# Altium schematic sheet transmitter.SchDoc
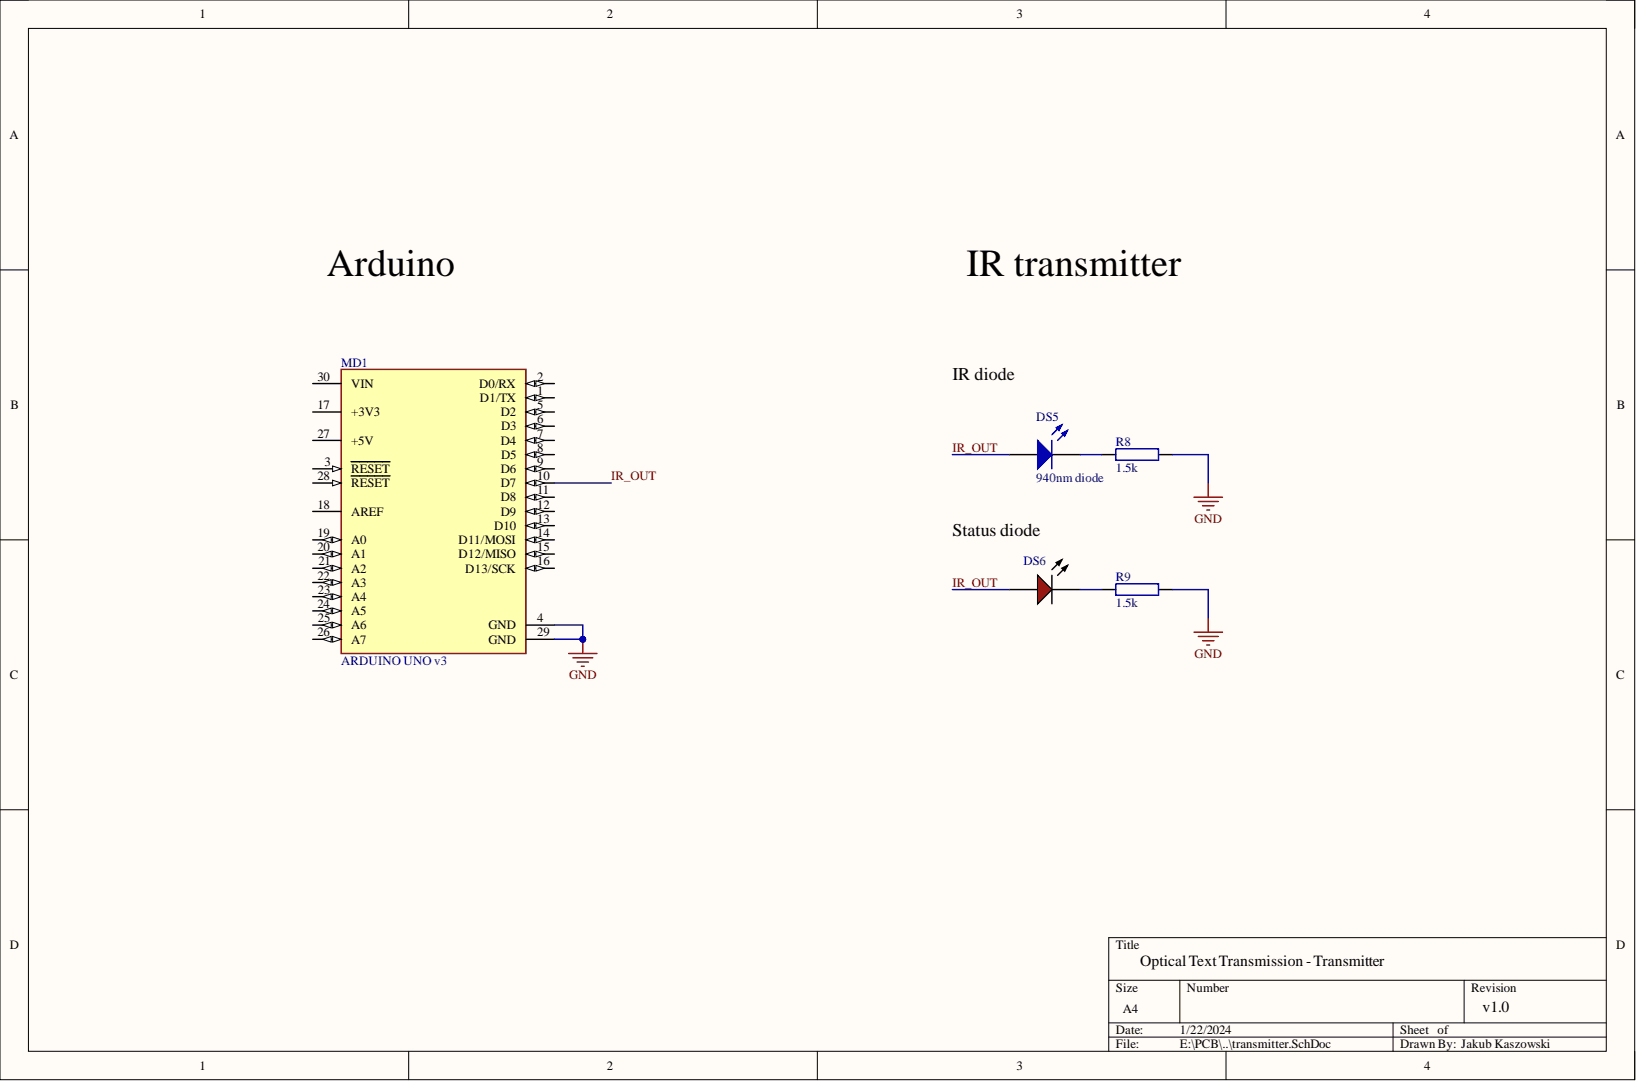
[diagram p0: transmitter.SchDoc]

## Parts
DS5  940nm diode  [VISH-TSAL6200]
DS6  TLMS1100-GS08  [VISH-TLMX1100_V]  (value not drawn)
MD1  ARDUINO UNO v3  [FP-A000005-MFG]
R8  1.5k  [R0603]
R9  1.5k  [R0603]

## Nets
GND: MD1.4(GND) MD1.29(GND) R8.2 R9.2
IR_OUT: DS5.1 DS6.1 MD1.10(D7)
NetDS5_2: DS5.2 R8.1
NetDS6_2: DS6.2 R9.1

Not connected: MD1 1(D1/TX) 2(D0/RX) 3(RESET) 5(D2) 6(D3) 7(D4) 8(D5) 9(D6) 11(D8) 12(D9) 13(D10) 14(D11/MOSI) 15(D12/MISO) 16(D13/SCK) 17(+3V3) 18(AREF) 19(A0) 20(A1) 21(A2) 22(A3) 23(A4) 24(A5) 25(A6) 26(A7) 27(+5V) 28(RESET) 30(VIN)

## Library data of the parts
DS5 = CMP-2000-07327-1: High Power Infrared Emitting Diode, GaAlAs, MQW, 1.35 V, 100 mA, -40 to 85 degC, 2-Pin THD, RoHS, Bulk; Manufacturer: Vishay; DELETED: Compliant; Forward Current: 100mA; Forward Voltage: 3V; High Brightness: Yes; Lens Type: Colored; Lum Intensity: 500mW/srmcd; Luminous Intensity: 500mW/sr; Main Category: IrLED; Number of  Elements: 1; Peak Wavelength: 950nm; Power Dissipation: 160W; Product Depth (mm): 5.8mm; Product Height (mm): 8.7mm; Rad Hardened: No; Reverse Voltage: 5V; Shape Type: Circular; Viewing Angle: 34deg; Chip Material: GaAlAs; Component Kind: Standard; Datasheet URL: http://www.vishay.com/docs/81010/tsal6200.pdf; Datasheet Version: Rev. 2.4, 03/2014; Lens Dimensions: 5x5x7.7mm; Library Name: Altium Content Vault; Manufacturer URL: http://www.vishay.com/; Mounting: ThroughHole; Mounting Technology: ThroughHole; Operating Temp Range: -40Cto85C; Operating Temperature Classification: Industrial; Package Description: 2-Pin Through Hole Device, Dia 5.8 mm, Pitch 2.54 mm; Package Reference: TSAL6200; Package Type: T-13/4; Packaging: Bulk; Pin Count: 2; Product Length (mm): 5.8mm; Test Current (It): 100mA; Type: IrLED
DS6 = CMP-1666-00027-1: LED, RED, 2.1 V, -40 to 100 degC, 2-Pin SMD (0603), RoHS, Tape and Reel; Capacitance: 12 pF; Resistance: 100000000000 Ohm; Tolerance: 5%; Type (Capacitors): C0G; Voltage Rating: 50 V; Case Code (Imperial): 0603; Case Code (Metric): 1608; Case/Package: 0603; Component Kind: Standard; ComponentLink1Description: Manufacturer URL; ComponentLink1URL: http://www.vishay.com/; ComponentLink2Description: Datasheet; ComponentLink2URL: http://www.vishay.com/docs/83173/tlmb1100.pdf; DatasheetVersion: Rev. 2.7, 03/2016; Height: 0.8 mm; Length: 1.6 mm; Library Name: Altium Content Vault; Max Operating Temperature: 125degC; Min Operating Temperature: -55degC; Mount: Surface Mount; Package Quantity: 4000; PackageDescription: 2-Pin Surface Mount Device, Body 1.6 x 0.8 mm; PackageReference: TLMX1100; Packaging: Tape and Reel; Pins: 2; Radiation Hardening: No; REACH SVHC: No SVHC; RoHSCompliant: True; Voltage Rating (DC): 50 V; Width: 0.8 mm
MD1 = CMP-189986-000002-1: ARDUINO NANO ATMEGA328 EVAL BRD; Core Architecture: AVR; Data Bus Width: 8b; Evaluation Kit: Yes; Interface: I2C; Number of Bits: 8; Operating Supply Voltage: 12V; Component Kind: Standard; Height: 0.25inch; Length: 7inch; Library Name: Altium Content Vault; Package Quantity: 50; Packaging: Bulk; REACH SVHC: No SVHC; Series: AVR® ATmega; Weight: 0.358383oz; Width: 5inch
R8 R9 = 1.5k 0603 0.1% 1/5W: Res Thick Film 0603 1.5K Ohm 0.1% 0.2W(1/5W) ±50ppm/C Pad SMD Automotive T/R; Manufacturer: Panasonic; Manufacturer Part Number: ERJ-PB3B1501V; Max Power Dissipation: 200mW; Resistance: 1.5kR; Temperature Coefficient: 50ppm/°C; Case Code (Imperial): 0603; Case Code (Metric): 1608; Case/Package: 0603; Category: Chip SMD Resistors; Component Kind: Standard; ComponentLink1Description: https://industrial.panasonic.com/sa/system/files/data/download/catalog/id_fixed-resistors_e_2.pdf?src-supplier=Digi-Key; ComponentLink1URL: https://industrial.panasonic.com/sa/system/files/data/download/catalog/id_fixed-resistors_e_2.pdf?src-supplier=Digi-Key; ComponentLink2Description: https://industrial.panasonic.com/cdbs/www-data/pdf/RDM0000/DMM0000COL5.pdf?src-supplier=Digi-Key; ComponentLink2URL: https://industrial.panasonic.com/cdbs/www-data/pdf/RDM0000/DMM0000COL5.pdf?src-supplier=Digi-Key; Features: Automotive AEC-Q200; Height - Seated (Max): 0.022inch; Length: 0.063inch; Library Name: RESISTORS.SchLib; Max Operating Temperature: 155°C; Min Operating Temperature: -55°C; Number of Terminations: 2; Packaging: Tape and Reel; Pricing 1: 1=0.25000, 10=0.15300, 50=0.11100, 100=0.06300, 1000=0.03400, 10000=0.02400 (USD); Resistor Type: Thick Film; RoHS: Yes; Stock 1: 11796; Supplier 1: Mouser; Supplier Part Number 1: 667-ERJ-PB3B1501V; Tolerance: 0.1%; Width: 0.032inch
